# Altium schematic sheet MCU.SchDoc
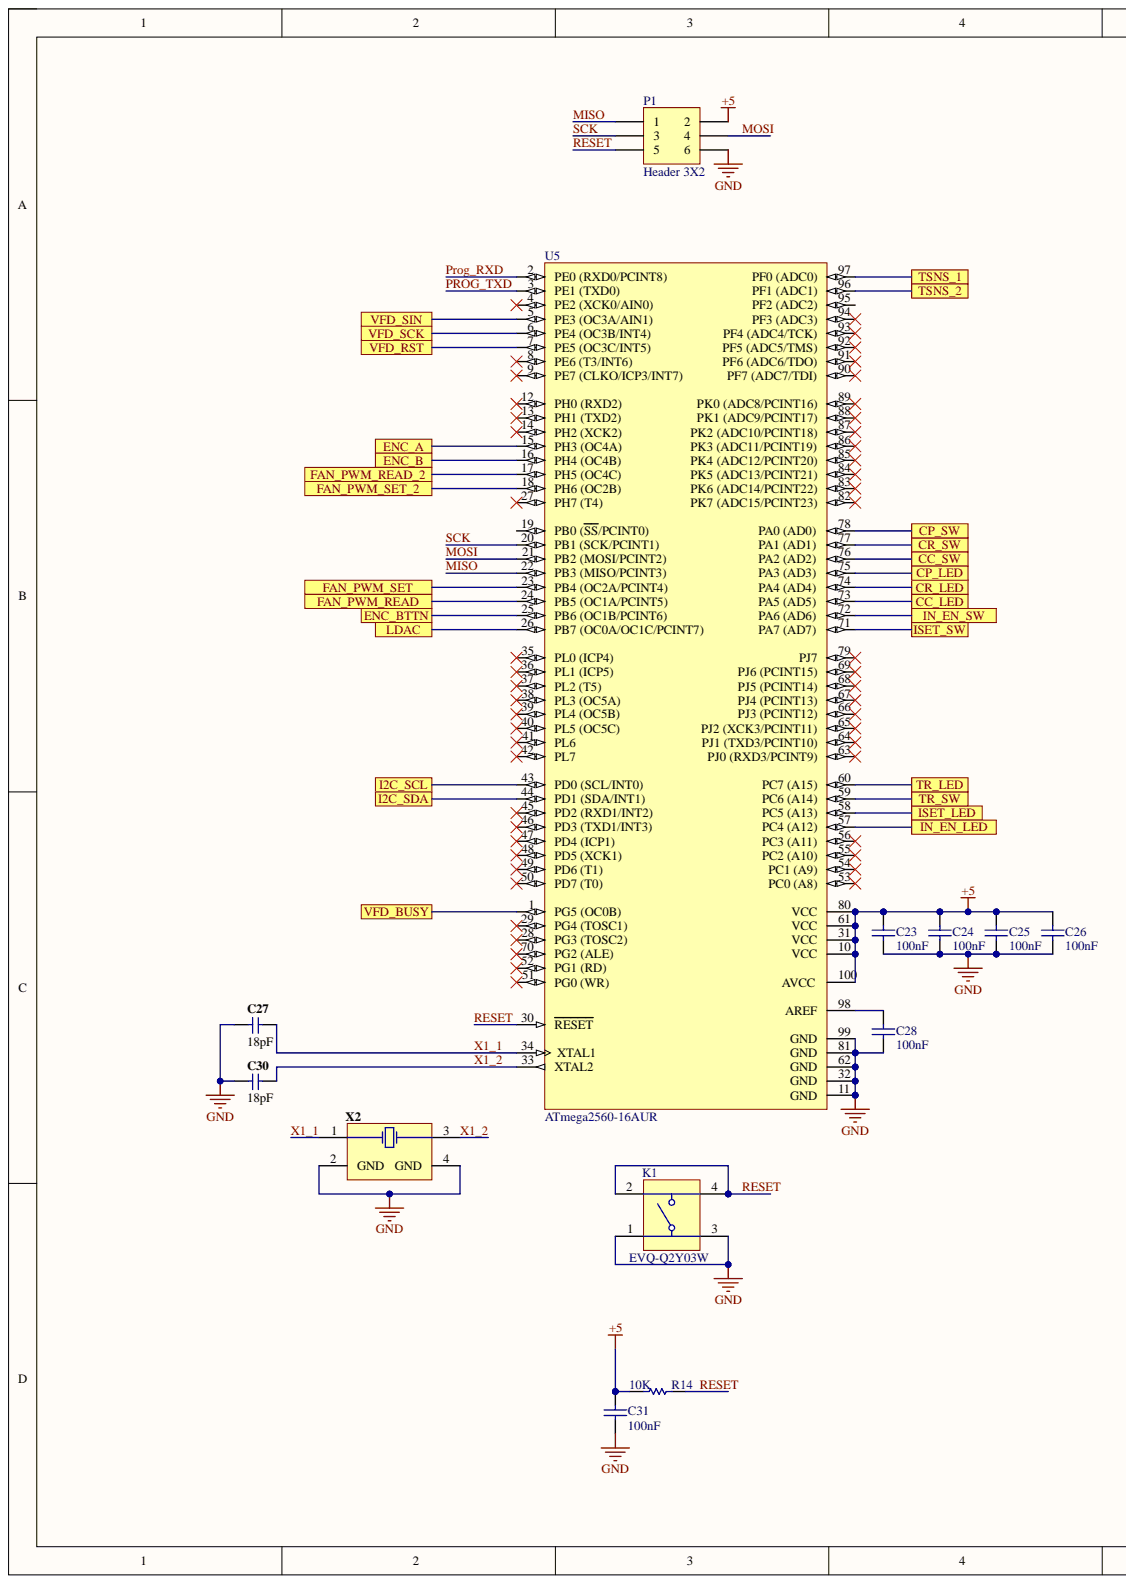
[diagram p0: MCU.SchDoc, left]
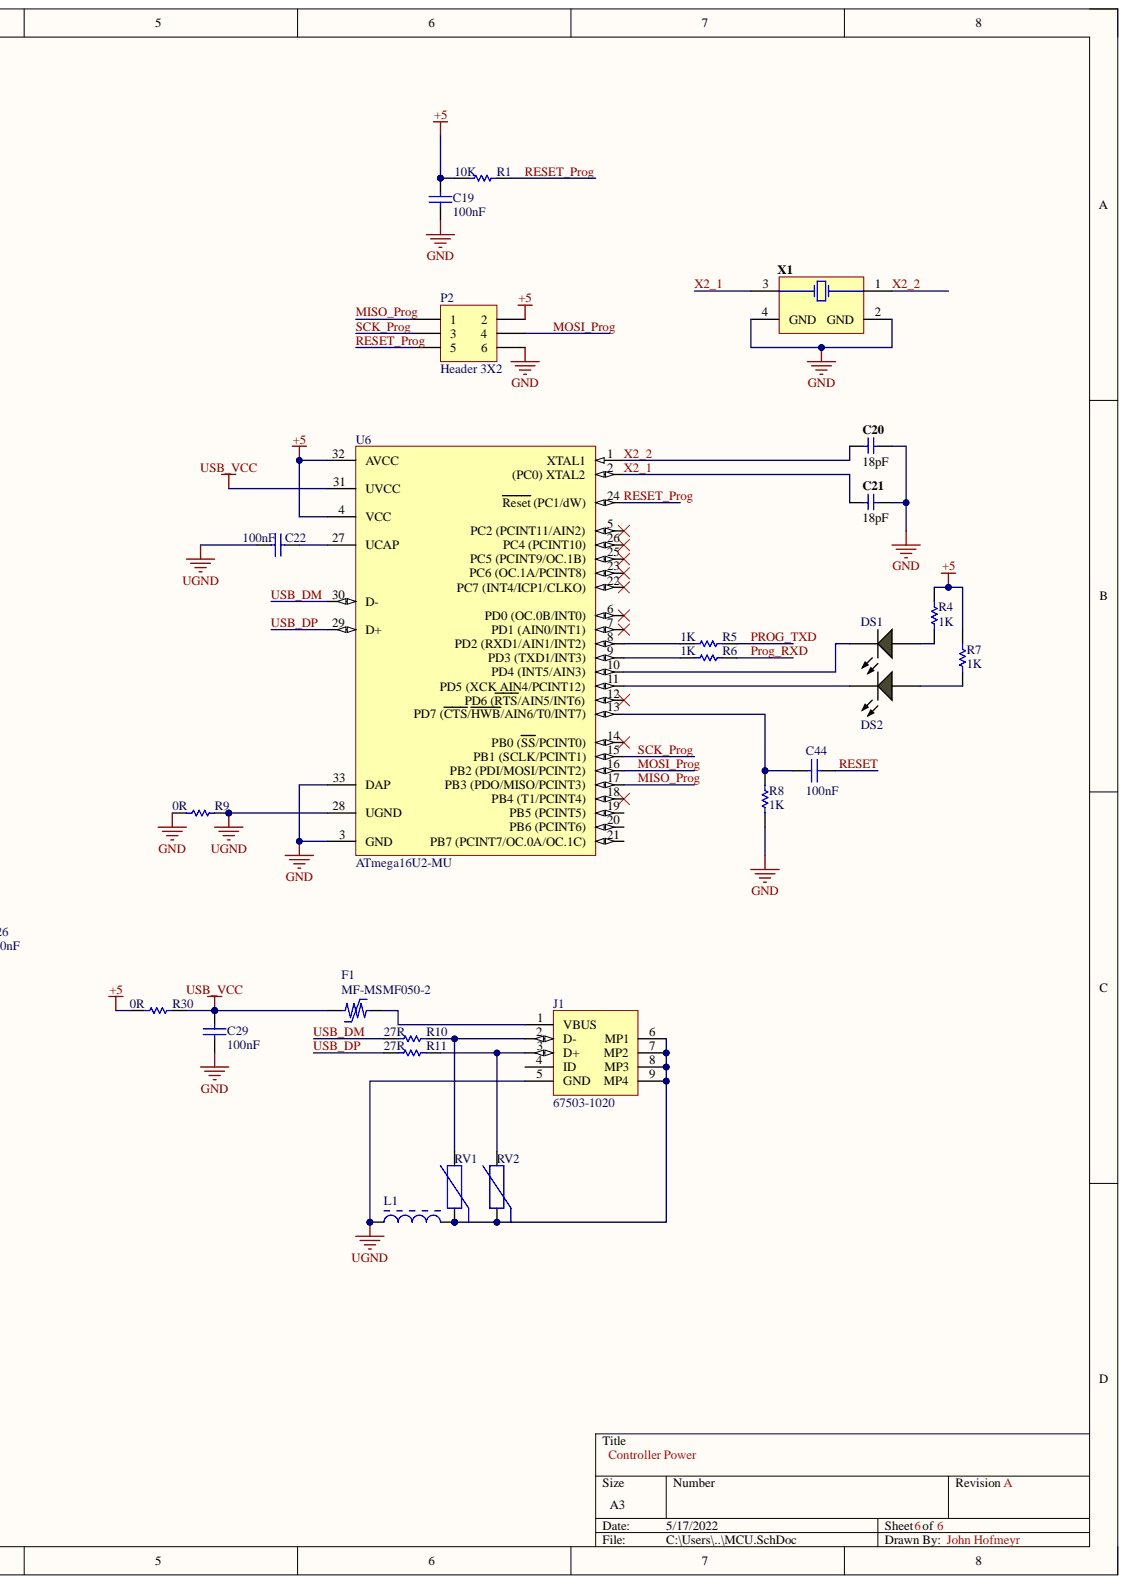
[diagram p1: MCU.SchDoc, right]

## Parts
C19  100nF  [CAPC2013X140X50ML20T25]
C20  18pF  [C0805]
C21  18pF  [C0805]
C22  100nF  [CAPC2013X140X50ML20T25]
C23  100nF  [CAPC2013X140X50ML20T25]
C24  100nF  [CAPC2013X140X50ML20T25]
C25  100nF  [CAPC2013X140X50ML20T25]
C26  100nF  [CAPC2013X140X50ML20T25]
C27  18pF  [C0805]
C28  100nF  [CAPC2013X140X50ML20T25]
C29  100nF  [CAPC2013X140X50ML20T25]
C30  18pF  [C0805]
C31  100nF  [CAPC2013X140X50ML20T25]
C44  100nF  [CAPC2013X140X50ML20T25]
DS1  HSMG-C190  [AVAG-HSMX-C190_V]  (value not drawn)
DS2  HSMG-C190  [AVAG-HSMX-C190_V]  (value not drawn)
F1  MF-MSMF050-2  [BOUR-MF-MSMF050-2_V]
J1  67503-1020  [MOLX-67503-1020_V]
K1  EVQ-Q2Y03W  [PNSC-EVQQ2_V]
L1  BLM21AH102SN1D  [INDC2013X105X50ML20T20]  (value not drawn)
P1  Header 3X2  [HDR2X3]
P2  Header 3X2  [HDR2X3]
R1  10K  [RESC2013X50X40NL20T20]
R4  1K  [RESC2013X50X40ML20T20]
R5  1K  [RESC2013X50X40ML20T20]
R6  1K  [RESC2013X50X40ML20T20]
R7  1K  [RESC2013X50X40ML20T20]
R8  1K  [RESC2013X50X40ML20T20]
R9  0R  [RESC2013X60X35ML10T20]
R10  27R  [RESC2013X50X40ML20T20]
R11  27R  [RESC2013X50X40ML20T20]
R14  10K  [RESC2013X50X40NL20T20]
R30  0R  [RESC2013X60X35ML10T20]
RV1  CG0603MLC-05E  [BOUR-CG0603-2_V]  (value not drawn)
RV2  CG0603MLC-05E  [BOUR-CG0603-2_V]  (value not drawn)
U5  ATmega2560-16AUR  [100A_L]
U6  ATmega16U2-MU  [32PG_N]
X1  ABM3B-16.000MHZ-B2-T  [ABRA-ABM3B-4_V]  (value not drawn)
X2  ABM3B-16.000MHZ-B2-T  [ABRA-ABM3B-4_V]  (value not drawn)

## Ports
CC_LED, CC_SW, CP_LED, CP_SW, CR_LED, CR_SW, ENC_A, ENC_B, ENC_BTTN, FAN_PWM_READ, FAN_PWM_READ_2, FAN_PWM_SET, FAN_PWM_SET_2, I2C_SCL, I2C_SDA, IN_EN_LED, IN_EN_SW, ISET_LED, ISET_SW, LDAC, TR_LED, TR_SW, TSNS_1, TSNS_2, VFD_BUSY, VFD_RST, VFD_SCK, VFD_SIN

## Nets
+5: C19.2 C23.2 C24.2 C25.2 C26.2 C31.2 P1.2 P2.2 R1.1 R4.2 R7.2 R14.1 R30.1 U5.10(VCC) U5.31(VCC) U5.61(VCC) U5.80(VCC) U5.100(AVCC) U6.4(VCC) U6.32(AVCC)
GND: C19.1 C20.1 C21.1 C23.1 C24.1 C25.1 C26.1 C27.1 C28.1 C29.1 C30.1 C31.1 K1.1 K1.3 P1.6 P2.6 R8.1 R9.1 U5.11(GND) U5.32(GND) U5.62(GND) U5.81(GND) U5.99(GND) U6.3(GND) U6.33(DAP) X1.2(GND) X1.4(GND) X2.2(GND) X2.4(GND)
UGND: C22.2 J1.5(GND) L1.1 R9.2 U6.28(UGND)
USB_VCC: C29.2 F1.2 R30.2 U6.31(UVCC)
CC_LED: U5.73(PA5_(AD5)) ; port CC_LED
CC_SW: U5.76(PA2_(AD2)) ; port CC_SW
CP_LED: U5.75(PA3_(AD3)) ; port CP_LED
CP_SW: U5.78(PA0_(AD0)) ; port CP_SW
CR_LED: U5.74(PA4_(AD4)) ; port CR_LED
CR_SW: U5.77(PA1_(AD1)) ; port CR_SW
ENC_A: U5.15(PH3_(OC4A)) ; port ENC_A
ENC_B: U5.16(PH4_(OC4B)) ; port ENC_B
ENC_BTTN: U5.25(PB6_(OC1B/PCINT6)) ; port ENC_BTTN
FAN_PWM_READ: U5.24(PB5_(OC1A/PCINT5)) ; port FAN_PWM_READ
FAN_PWM_READ_2: U5.17(PH5_(OC4C)) ; port FAN_PWM_READ_2
FAN_PWM_SET: U5.23(PB4_(OC2A/PCINT4)) ; port FAN_PWM_SET
FAN_PWM_SET_2: U5.18(PH6_(OC2B)) ; port FAN_PWM_SET_2
I2C_SCL: U5.43(PD0_(SCL/INT0)) ; port I2C_SCL
I2C_SDA: U5.44(PD1_(SDA/INT1)) ; port I2C_SDA
IN_EN_LED: U5.57(PC4_(A12)) ; port IN_EN_LED
IN_EN_SW: U5.72(PA6_(AD6)) ; port IN_EN_SW
ISET_LED: U5.58(PC5_(A13)) ; port ISET_LED
ISET_SW: U5.71(PA7_(AD7)) ; port ISET_SW
LDAC: U5.26(PB7_(OC0A/OC1C/PCINT7)) ; port LDAC
MISO: P1.1 U5.22(PB3_(MISO/PCINT3))
MISO_Prog: P2.1 U6.17
MOSI: P1.4 U5.21(PB2_(MOSI/PCINT2))
MOSI_Prog: P2.4 U6.16(PB2_(PDI/MOSI/PCINT2))
Prog_RXD: R6.2 U5.2(PE0_(RXD0/PCINT8))
PROG_TXD: R5.2 U5.3(PE1_(TXD0))
RESET: C44.1 K1.2 K1.4 P1.5 R14.2 U5.30(RESET)
RESET_Prog: P2.5 R1.2 U6.24(Reset_(PC1/dW))
SCK: P1.3 U5.20(PB1_(SCK/PCINT1))
SCK_Prog: P2.3 U6.15(PB1_(SCLK/PCINT1))
TR_LED: U5.60(PC7_(A15)) ; port TR_LED
TR_SW: U5.59(PC6_(A14)) ; port TR_SW
TSNS_1: U5.97(PF0_(ADC0)) ; port TSNS_1
TSNS_2: U5.96(PF1_(ADC1)) ; port TSNS_2
USB_DM: R10.1 U6.30(D-)
USB_DP: R11.1 U6.29(D+)
VFD_BUSY: U5.1(PG5_(OC0B)) ; port VFD_BUSY
VFD_RST: U5.7(PE5_(OC3C/INT5)) ; port VFD_RST
VFD_SCK: U5.6(PE4_(OC3B/INT4)) ; port VFD_SCK
VFD_SIN: U5.5(PE3_(OC3A/AIN1)) ; port VFD_SIN
X1_1: C27.2 U5.34(XTAL1) X2.1
X1_2: C30.2 U5.33(XTAL2) X2.3
X2_1: C21.2 U6.2((PC0)_XTAL2) X1.3
X2_2: C20.2 U6.1(XTAL1) X1.1
NetC22_1: C22.1 U6.27(UCAP)
NetC28_2: C28.2 U5.98(AREF)
NetC44_2: C44.2 R8.2 U6.13(PD7_(CTS/HWB/AIN6/T0/INT7))
NetDS1_1: DS1.1 R4.1
NetDS1_2: DS1.2 U6.10(PD4_(INT5/AIN3))
NetDS2_1: DS2.1 R7.1
NetDS2_2: DS2.2 U6.11(PD5_(XCK_AIN4/PCINT12))
NetF1_1: F1.1 J1.1(VBUS)
NetJ1_2: J1.2(D-) R10.2 RV1.2
NetJ1_3: J1.3(D+) R11.2 RV2.2
NetJ1_6: J1.6(MP1) J1.7(MP2) J1.8(MP3) J1.9(MP4) L1.2 RV1.1 RV2.1
NetR5_1: R5.1 U6.8(PD2_(RXD1/AIN1/INT2))
NetR6_1: R6.1 U6.9(PD3_(TXD1/INT3))

Not connected: J1 4(ID); U5 4(PE2_(XCK0/AIN0)) 8(PE6_(T3/INT6)) 9(PE7_(CLKO/ICP3/INT7)) 12(PH0_(RXD2)) 13(PH1_(TXD2)) 14(PH2_(XCK2)) 19(PB0_(SS/PCINT0)) 27(PH7_(T4)) 28(PG3_(TOSC2)) 29(PG4_(TOSC1)) 35(PL0_(ICP4)) 36(PL1_(ICP5)) 37(PL2_(T5)) 38(PL3_(OC5A)) 39(PL4_(OC5B)) 40(PL5_(OC5C)) 41(PL6) 42(PL7) 45(PD2_(RXD1/INT2)) 46(PD3_(TXD1/INT3)) 47(PD4_(ICP1)) 48(PD5_(XCK1)) 49(PD6_(T1)) 50(PD7_(T0)) 51(PG0_(WR)) 52(PG1_(RD)) 53(PC0_(A8)) 54(PC1_(A9)) 55(PC2_(A10)) 56(PC3_(A11)) 63(PJ0_(RXD3/PCINT9)) 64(PJ1_(TXD3/PCINT10)) 65(PJ2_(XCK3/PCINT11)) 66(PJ3_(PCINT12)) 67(PJ4_(PCINT13)) 68(PJ5_(PCINT14)) 69(PJ6_(PCINT15)) 70(PG2_(ALE)) 79(PJ7) 82(PK7_(ADC15/PCINT23)) 83(PK6_(ADC14/PCINT22)) 84(PK5_(ADC13/PCINT21)) 85(PK4_(ADC12/PCINT20)) 86(PK3_(ADC11/PCINT19)) 87(PK2_(ADC10/PCINT18)) 88(PK1_(ADC9/PCINT17)) 89(PK0_(ADC8/PCINT16)) 90(PF7_(ADC7/TDI)) 91(PF6_(ADC6/TDO)) 92(PF5_(ADC5/TMS)) 93(PF4_(ADC4/TCK)) 94(PF3_(ADC3)) 95(PF2_(ADC2)); U6 5(PC2_(PCINT11/AIN2)) 6(PD0_(OC.0B/INT0)) 7(PD1_(AIN0/INT1)) 12(PD6_(RTS/AIN5/INT6)) 14(PB0_(SS/PCINT0)) 18(PB4_(T1/PCINT4)) 19(PB5_(PCINT5)) 20(PB6_(PCINT6)) 21 22(PC7_(INT4/ICP1/CLKO)) 23(PC6_(OC.1A/PCINT8)) 25(PC5_(PCINT9/OC.1B)) 26(PC4_(PCINT10))

## Library data of the parts
C19 C22-C26 C28 C29 C31 C44 = CMP-2007-04689-1: Manufacturer: AVX Interconnect / Elco; Part Number: 08055D104KAT2A; Component Kind: Standard; Library Name: Altium Content Vault
C20 C21 C27 C30 = C0805C200J5GACTU: KEMET         C0805C200J5GACTU             SMD Multilayer Ceramic Capacitor, 0805 [2012 Metric], 20 pF, 50 V,  5%, C0G / NP0, C Series                          New; Capacitance: 20pF; Voltage Rating (DC): 50V; Case/Package: 0805; Category: Ceramic Capacitors; Component Kind: Standard; ComponentLink1Description: https://datasheet.ciiva.com/5414/kem-c1003-c0g-smd-356956-5414200.pdf?src-supplier=Mouser; ComponentLink1URL: https://datasheet.ciiva.com/5414/kem-c1003-c0g-smd-356956-5414200.pdf?src-supplier=Mouser; Depth: 1.25mm; Dielectric: C0G; Dielectric Material: Ceramic; Height: 0.78mm; Lead Free: Lead Free; Length: 2mm; Library Name: DCLoadV2.SchLib; Manufacturer: KEMET; Manufacturer Part Number: C0805C200J5GACTU; Max Operating Temperature: 125°C; Min Operating Temperature: -55°C; Mount: Surface Mount; Packaging: Tape and Reel; Pricing 1: 1=0.32000, 10=0.21800, 50=0.14520, 100=0.12340, 500=0.08712, 1000=0.07623 (USD); Radiation Hardening: No; RoHS: Yes; Stock 1: 51104; Supplier 1: Digi-Key; Supplier Part Number 1: 399-8033-1-ND; Tolerance: 5%
DS1 DS2 = CMP-1744-00002-1: Chip LED, Green, 2.2 V, -40 to 85 degC, 2-Pin SMD, RoHS, Tape and Reel; Access Time: 3500 ns; Case\Package: SOIC; Clock Frequency: 100 KHz; Density: 32 kb; Frequency: 400 KHz; Interface: Serial; Max Supply Voltage: 5.5 V; Min Supply Voltage: 1.7 V; Nominal Supply Current: 2 mA; Component Kind: Standard; ComponentLink1Description: Manufacturer URL; ComponentLink1URL: http://www.avagotech.com/; ComponentLink2Description: Datasheet; ComponentLink2URL: http://docs.avagotech.com/docs/AV02-0551EN; ComponentLink3Description: Package Specification; ComponentLink3URL: http://www.fairchildsemi.com/dwg/MA/MA03D.pdf; DatasheetVersion: Mar-12; Height: 1.5 mm; Length: 5 mm; Library Name: Altium Content Vault; Max Clock Frequency: 100 KHz; Max Operating Temperature: 85 degC; Min Operating Temperature: -40 degC; Mount: Surface Mount; Package Quantity: 3000; PackageDescription: 2-Pin Surface Mount Device, Body 1.6 x 0.8 mm, Height 0.9 mm; PackageReference: HSMX-C190; Packaging: Tape and Reel; Pins: 8; Radiation Hardening: No; REACH SVHC: No SVHC; RoHSCompliant: true; Width: 4 mm
F1 = CMP-2100-03653-1: PTC Resettable Fuse, 15 V, 500 mA, -40 to 85 degC, 2-Pin SMD, RoHS, Tape and Reel; Manufacturer: Bourns; Current Rating: 100A; Power Dissipation: 800mW; Resistance: 150mOhm; Supply Voltage: 15V; Case Code (Imperial): 1812; Case Code (Metric): 4532; Case/Package: 1812; Component Kind: Standard; Contact Plating: Gold; Datasheet URL: http://www.bourns.com/docs/Product-Datasheets/mfmsmf.pdf; Datasheet Version: Rev. AG, 08/2016; Height: 0.85mm; Lead Free: LeadFree; Length: 4.73mm; Library Name: Altium Content Vault; Manufacturer URL: http://www.bourns.com/; Max Current Rating: 100A; Max Operating Temperature: 85degC; Min Operating Temperature: -40degC; Mount: SurfaceMount; Number of Terminals: 2; Package Description: 2-Pin Surface Mount Device, Body 4.55 x 3.24 mm; Package Quantity: 2000; Package Reference: MF-MSMF050-2; Packaging: TapeandReel; Radiation Hardening: No; REACH SVHC: NoSVHC; RoHSCompliant: true; Termination: SMD/SMT; Voltage Rating: 15V; Voltage Rating (DC): 15V; Width: 3.41mm
J1 = CMP-0411-00002-1: USB, MINI, SMD, RA KME04-USBMU03A01; Manufacturer: Molex; Component Kind: Standard; ComponentLink1Description: Manufacturer URL; ComponentLink1URL: http://www.molex.com/; ComponentLink2Description: Datasheet; ComponentLink2URL: http://www.molex.com/pdm_docs/sd/675031020_sd.pdf; DatasheetVersion: Rev F, 06/2009; Library Name: Altium Content Vault; Mounting Technology: Surface Mount; PackageDescription: Mini USB Connector, SMT, Righer Angle; PackageReference: 67503-1020; PartNumber: 67503-1020; Terminal Pitch: 0.8 mm
K1 = CMP-2000-06232-1: Light Touch Switche, 3.5 N Force, Height 3.1 mm, -40 to 85 degC, 4-Pin SMD, RoHS, Tape and Reel; Manufacturer: Panasonic; Actuation Type: Top; Actuator / Cap Colour: White; Body Depth: 6mm; Body Width: 6.5mm; Contact Configuration: SPST-NO; Contact Current DC Max: 20mA; Contact Resistance Max: 100mohm; Contact Voltage AC Max: 250V; Contact Voltage DC Max: 15V; External Depth: 1.8mm; External Length / Height: 3.1mm; No. of Mechanical Operations: 100000; No. of Poles: 1; Operating Force: 3.5N; SVHC: NoSVHC(16-Dec-2013); Switch Operation: Off-On; Switch Terminals: Solder; Component Kind: Standard; Contact Current Max: 20mA; Contact Current Rating: 20mA; Contact Voltage DC Nom: 15V; Datasheet URL: http://download.siliconexpert.com/pdfs/2014/10/4/5/54/9/232/pns_/manual/8atk0000ce28.pdf; Datasheet Version: 09/2014; Dielectric Strength VAC: 250V; External Width: 6.5mm; Height: 3.1mm; Library Name: Altium Content Vault; Manufacturer URL: http://www.panasonic.com/; Mounting Technology: SurfaceMount; No. of Ways: 1; Operating Force Min: 3.5N; Operating Temperature Max: 85°C; Operating Temperature Min: -40°C; Package Description: 4-Pin Surface Mount Device, Body 6.5 x 6 mm; Package Reference: EVQQ2; Series: EVQQ2; Terminal Type: SMD
L1 = CMP-2000-05954-1: Chip Bead, 1000 Ohm, +/- 25%, 200 mA, -55 to 85 degC, 2-Pin SMD, RoHS, Tape and Reel; Manufacturer: Murata; Brand: MurataElectronics; Case Height: 0.85mm; Case Length: 2mm; Case Width: 1.25mm; Impedance: 1kOhms; Maximum DC Current: 200mA; Maximum DC Resistance: 450mOhms; Test Frequency: 100MHz; Component Kind: Standard; Datasheet URL: http://datasheet.octopart.com/BLM21AH102SN1D-Murata-datasheet-629859.pdf; Datasheet Version: 11/2017; Dimensions: 1.25mmWx2mmLx0.85mmH; Factory Pack Quantity: 4000; Library Name: Altium Content Vault; Manufacturer URL: http://www.murata.com/; Mounting Technology: SurfaceMount; Operating Temperature Range: -55Cto+125C; Package / Case: 0805(2012metric); Package Description: 2-Pin Surface Mount Device, Body 2 x1.25 mm; Package Reference: 0805; Packaging: Reel; Product: FerriteChipBeads; Product Category: EMIFilterBeads,Chips&Arrays; RoHS: Details; Series: BLM21A; Termination Style: SMD/SMT; Tolerance: 25%
P1 P2 = Header 3X2: Header, 3-Pin, Dual row; Component Kind: Standard; LatestRevisionDate: 17-Jul-2002; LatestRevisionNote: Re-released for DXP Platform.; Library Name: Miscellaneous Connectors.IntLib; Signal Integrity: Connector; Publisher: Altium Limited
R1 R14 = CMP-2001-03215-1: Manufacturer: Susumu; Part Number: RR1220P-103-D; Component Kind: Standard; Library Name: Altium Content Vault
R4-R8 = CMP-2001-03210-1: Manufacturer: Susumu; Part Number: RR1220P-102-D; Component Kind: Standard; Library Name: Altium Content Vault
R9 R30 = CMP-2100-03673-1: Chip Resistor, 0 Ohm, Jumper, +/- 5%, 0.125 W, -55 to 155 degC, 0805 (2012 Metric), RoHS, Tape and Reel; Manufacturer: Yageo; Power Rating: 125mW; Resistance: 0mOhm; Temperature Coefficient: 200ppm/degreesC; Voltage Rating: 150V; Case Code (Imperial): 0805; Case Code (Metric): 2012; Case/Package: 0805; Component Kind: Standard; Composition: ThickFilm; Contact Plating: Tin; Datasheet URL: http://www.yageo.com/NewPortal/yageodocoutput?fileName=/pdf/R-Chip/PYu-RC_Group_51_RoHS_L_5.pdf; Datasheet Version: Rev. 5, 10/2016; Depth: 1.25mm; Height: 0.5mm; Lead Free: LeadFree; Length: 2mm; Library Name: Altium Content Vault; Manufacturer URL: http://www.yageo.com/; Max Operating Temperature: 155degC; Military Standard: Not; Min Operating Temperature: -55degC; Number of Pins: 2; Package Description: 2-Pin Surface Mounted Device, Body 2 x 1.25 mm; Package Reference: 0805; Packaging: TapeandReel; Radiation Hardening: No; REACH SVHC: Unknown; RoHSCompliant: true; Termination: SMD/SMT; Tolerance: 5%; Voltage Rating (DC): 150V; Width: 1.25mm
R10 R11 = CMP-2001-03547-1: Manufacturer: Susumu; Part Number: RR1220Q-220-D; Component Kind: Standard; Library Name: Altium Content Vault
RV1 RV2 = CMP-0584-00001-2: ESD Protector, 5 V Supply, -40 to 85 degC, 1.6 x 0.8 x 0.55 mm SMD, RoHS, Tape and Reel; Manufacturer: Bourns; CO: 0.5 pF; RT: 1 ns; VC: 20 V; VDC: 5 V; VT: 150 V; Component Kind: Standard; ComponentLink1Description: Manufacturer URL; ComponentLink1URL: http://www.bourns.com/; ComponentLink2Description: Datasheet; ComponentLink2URL: http://www.bourns.com/data/global/pdfs/MLC.pdf; DatasheetVersion: Rev. S; Library Name: Altium Content Vault; Mounting Technology: Surface Mount; Operating Temperature: -40 to 85 degC; PackageDescription: 2-Pin SMD, Body 1.6 x 0.8 mm, Pitch 1.52 mm; PackageReference: CG0603-2; Packing: Tape and Reel; PartNumber: CG0603MLC-05E; RoHS: TRUE
U5 = CMP-0095-00523-1: 8-bit AVR Microcontroller, 4.5-5.5V, 16MHz, 256KB Flash, 4KB EEPROM, 8KB SRAM, 86 GPIO pins, 100-pin TQFP, Industrial Grade (-40°C to 85°C), Pb-Free, Reel; Code_JEDEC: MS-026-AED; Component Kind: Standard; ComponentLink1Description: Manufacturer URL; ComponentLink1URL: http://www.atmel.com/; ComponentLink2Description: ATmega640/1280/1281/2560/2561 Datasheet; ComponentLink2URL: http://www.atmel.com/dyn/resources/prod_documents/doc2549.pdf; ComponentLink3Description: ATmega640/1280/1281/2560/2561 Summary; ComponentLink3URL: http://www.atmel.com/dyn/resources/prod_documents/2549S.pdf; DatasheetVersion: revN, May-2011; Library Name: Altium Content Vault; PackageDescription: 100-Pin Thin Profile Plastic Quad Flat Pack (TQFP), 14 x 14 x 1.0 mm Body, 0.5 mm Pitch; PackageReference: 100A; PackageVersion: revD, Oct-2010
U6 = CMP-0095-00115-3: 8-bit AVR Microcontroller, 2.7-5.5V, 16KB Flash, 512 Bytes EEPROM, 512 Bytes SRAM, 32-pin VQFN, Industrial Grade (-40°C to 85°C); Code_JEDEC: MO-220WHHD-2; Component Kind: Standard; ComponentLink1Description: Manufacturer URL; ComponentLink1URL: http://www.atmel.com/; ComponentLink2Description: ATmega8U2/16U2/32U2 Datasheet; ComponentLink2URL: http://www.atmel.com/dyn/resources/prod_documents/doc7799.pdf; ComponentLink3Description: ATmega8U2/16U2/32U2 Summary; ComponentLink3URL: http://www.atmel.com/dyn/resources/prod_documents/7799S.pdf; DatasheetVersion: revD, Nov-2010; Library Name: Altium Content Vault; PackageDescription: 32-Pin Quad Flat No-Lead (QFN) Sawn,  5 x 5 x 1.0 mm Body, Pitch 0.50 mm, 3.60mm Exposed Pad; PackageReference: 32PG; PackageVersion: revA, Oct-2008; PartNumber: ATmega16U2-MU; RevisionNote: Schematic symbol corrected
X1 X2 = CMP-1688-00003-1: Ceramic SMD Crystal, 16 MHz, +/- 20 ppm, 50 Ohm, -20 to 70 degC, 4-Pin SMD, RoHS, Tape and Reel; Current Rating: 1.82 A; Resistance: 0.033 Ohm; Shielding: Shielded; Tolerance: 30%; Case/Package: 5028; Component Kind: Standard; ComponentLink1Description: Manufacturer URL; ComponentLink1URL: http://www.abracon.com/; ComponentLink2Description: Datasheet; ComponentLink2URL: http://www.abracon.com/Resonators/abm3b.pdf; DatasheetVersion: Aug-15; Height: 2.8 mm; Length: 0.197 inch; Library Name: Altium Content Vault; Max Operating Temperature: 105degC; Min Operating Temperature: -40degC; Mount: Surface Mount; Package Quantity: 500; PackageDescription: 4-Pin Surface Mount Device, Body 5 x 3.2 mm; PackageReference: ABM3B; Packaging: Tape and Reel; REACH SVHC: Unknown; RoHSCompliant: True; Width: 0.197 inch
